# Altium schematic sheet heater_control.SchDoc
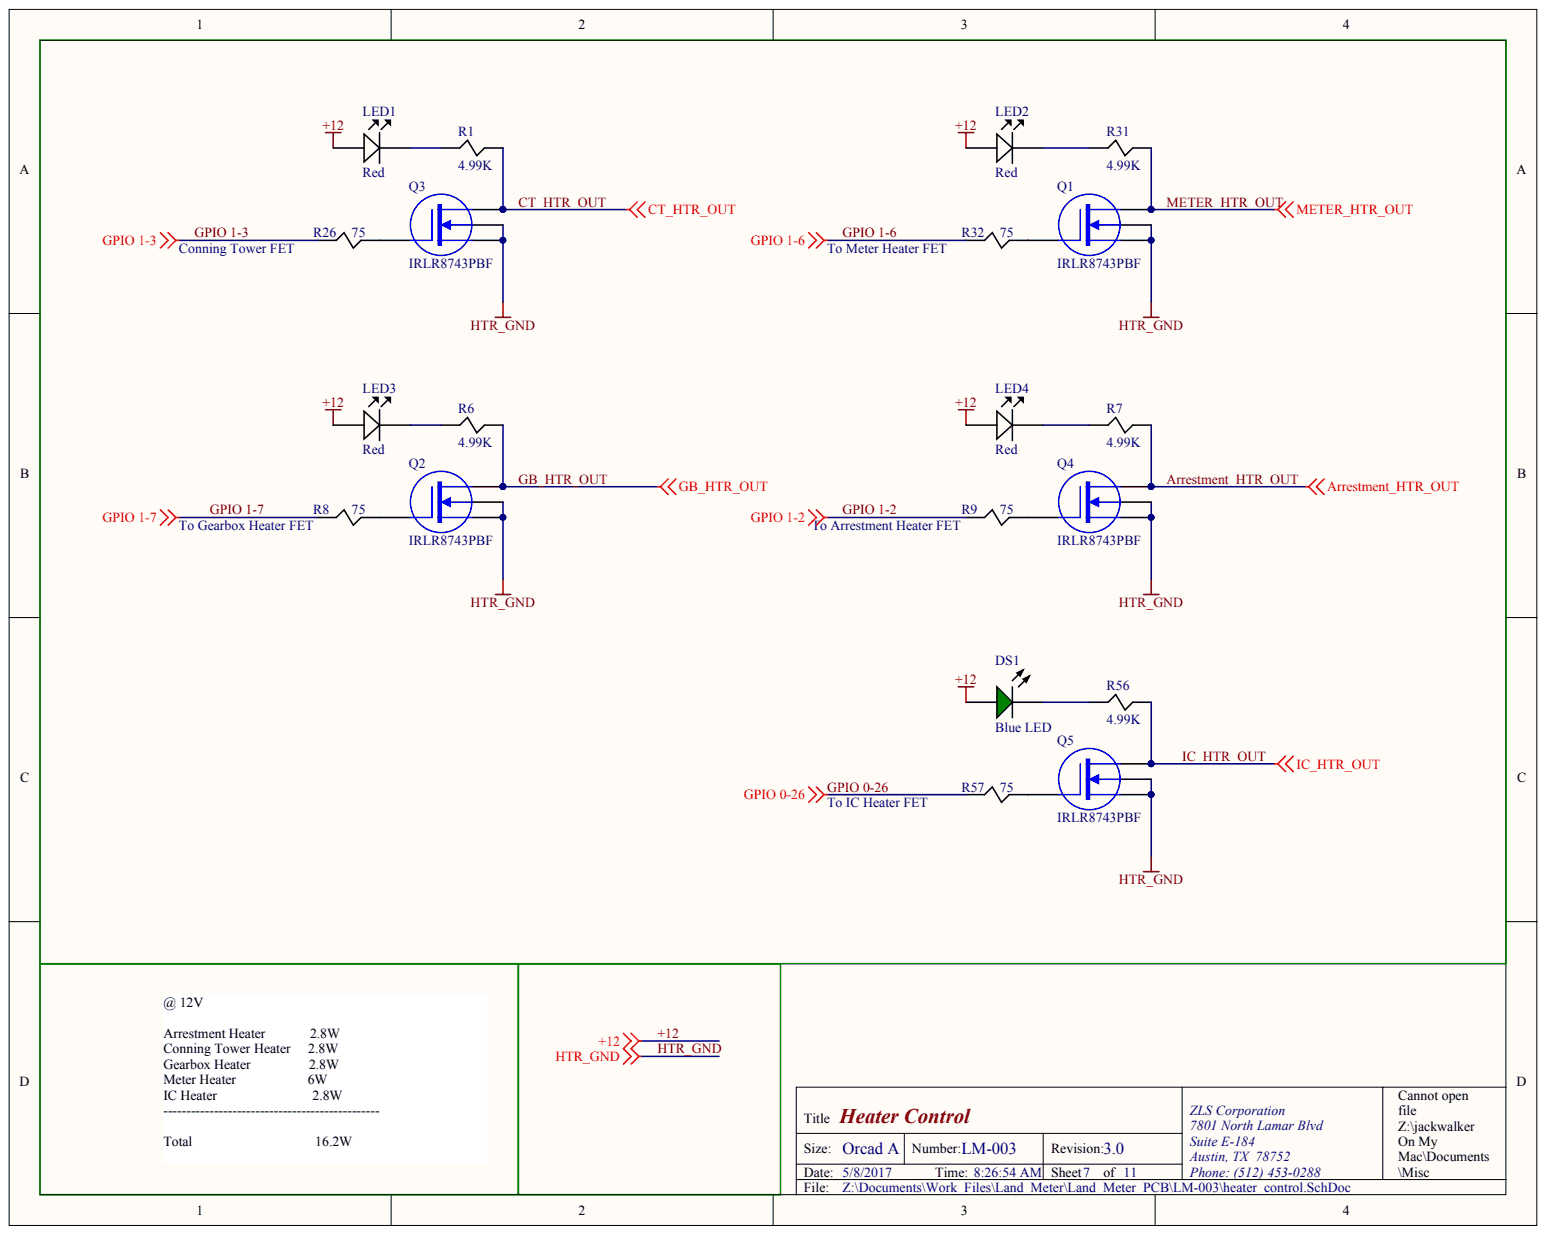
[diagram p0: heater_control.SchDoc]

## Parts
DS1  Blue LED  Color: Blue
LED1  Red
LED2  Red
LED3  Red
LED4  Red
Q1  IRLR8743PBF
Q2  IRLR8743PBF
Q3  IRLR8743PBF
Q4  IRLR8743PBF
Q5  IRLR8743PBF
R1  4.99K
R6  4.99K
R7  4.99K
R8  75
R9  75
R26  75
R31  4.99K
R32  75
R56  4.99K
R57  75

## Nets
+12: DS1.1 LED1.A LED2.A LED3.A LED4.A
ARRESTMENT_HTR_OUT: Q4.2 R7.2
CT_HTR_OUT: Q3.2 R1.2
GB_HTR_OUT: Q2.2 R6.2
GPIO 0-26: R57.1
GPIO 1-2: R9.1
GPIO 1-3: R26.1
GPIO 1-6: R32.1
GPIO 1-7: R8.1
HTR_GND: Q1.3 Q1.4 Q2.3 Q2.4 Q3.3 Q3.4 Q4.3 Q4.4 Q5.3 Q5.4
IC_HTR_OUT: Q5.2 R56.2
METER_HTR_OUT: Q1.2 R31.2
NetDS1_2: DS1.2 R56.1
NetLED1_K: LED1.K R1.1
NetLED2_K: LED2.K R31.1
NetLED3_K: LED3.K R6.1
NetLED4_K: LED4.K R7.1
NetQ1_1: Q1.1 R32.2
NetQ2_1: Q2.1 R8.2
NetQ3_1: Q3.1 R26.2
NetQ4_1: Q4.1 R9.2
NetQ5_1: Q5.1 R57.2

## Library data of the parts
DS1 = (no symbol name): Manufacturer 1: Lite-On Inc; Manufacturer Part Number 1: LTST-C191TBKT; Description 1: LED 468NM BLUE CLEAR 0603 SMD; Category 1: Optoelectronics; Wavelength - Dominant: 467.5nm; Wavelength - Peak: 468nm; Millicandela Rating: 30mcd; Voltage - Forward (Vf) (Typ): 3.4V; Current - Test: 20mA; Viewing Angle: 130°; Lens Type: Clear; Lens Style/Size: Rectangle with Flat Top, 1.1mm x 0.8mm; Supplier 1: Digi-Key; Supplier Part Number 1: 160-1647-1-ND; RoHS 1: RoHS Compliant; Stock 1: 267074; Pricing 1: 1=0.46, 10=0.342, 100=0.1813, 250=0.12824, 500=0.10944, 1000=0.0855, 2500=0.07524 (USD); Packaging: Cut Tape (CT); Package / Case: 0603 (1608 Metric); Size / Dimension: 1.60mm L x 0.80mm W; Height: 0.55mm; Mounting Type: Surface Mount; Luminous Flux @ Current - Test: -; ComponentLink1URL: http://optoelectronics.liteon.com/upload/download/DS22-2000-230/S_110_LTST-C191TBKT.pdf; ComponentLink1Description: http://optoelectronics.liteon.com/upload/download/DS22-2000-230/S_110_LTST-C191TBKT.pdf
LED1-LED4 = (no symbol name): Manufacturer 1: Dialight; Manufacturer Part Number 1: 5660406F; Description 1: LED 2MM X 5MM RT ANGLE RED PCMNT; Category 1: Optoelectronics; Wavelength - Peak: 635nm; Configuration: Single; Current: 20mA; Millicandela Rating: 7.4mcd; Viewing Angle: 140°; Lens Type: Diffused, Tinted; Lens Style/Size: Rectangle with Flat Top, 5.00mm x 2.00mm; Voltage Rating: 2V; Supplier 1: Digi-Key; Supplier Part Number 1: 350-1729-ND; RoHS 1: RoHS Compliant; Stock 1: 530; Pricing 1: 1=1.96, 10=1.595, 25=1.2272, 100=1.1044, 250=0.98172, 500=0.83446, 1000=0.6872, 2500=0.65284, 5000=0.63812 (USD); Packaging: Bulk; Part Status: Active; Color: Red; Mounting Type: Through Hole, Right Angle; ComponentLink1URL: http://www.dialight.com/Assets/Brochures_And_Catalogs/Indication/566-xx06.pdf; ComponentLink1Description: http://www.dialight.com/Assets/Brochures_And_Catalogs/Indication/566-xx06.pdf
Q1-Q5 = (no symbol name): Manufacturer 1: Infineon Technologies; Description 1: MOSFET N-CH 30V 160A DPAK; Category 1: Discrete Semiconductor Products; FET Type: N-Channel; Drain to Source Voltage (Vdss): 30V; Current - Continuous Drain (Id) @ 25°C: 160A (Tc); Drive Voltage (Max Rds On,  Min Rds On): 4.5V, 10V; Vgs(th) (Max) @ Id: 2.35V @ 100µA; Gate Charge (Qg) (Max) @ Vgs: 59nC @ 4.5V; Input Capacitance (Ciss) (Max) @ Vds: 4880pF @ 15V; Vgs (Max): ±20V; Power Dissipation (Max): 135W (Tc); Rds On (Max) @ Id, Vgs: 3.1 mOhm @ 25A, 10V; Supplier 1: Digi-Key; Supplier Part Number 1: IRLR8743PBF-ND; Manufacturer Part Number 1: IRLR8743PBF; RoHS 1: RoHS Compliant; Stock 1: 557; Pricing 1: 1=1.29, 10=1.152, 100=0.8984, 500=0.74214, 1000=0.5859 (USD); Packaging: Tube; Part Status: Not For New Designs; Technology: MOSFET (Metal Oxide); FET Feature: -; Operating Temperature: -55°C ~ 175°C (TJ); Mounting Type: Surface Mount; Supplier Device Package: D-Pak; Package / Case: TO-252-3, DPak (2 Leads + Tab), SC-63; ComponentLink1URL: http://www.irf.com/product-info/datasheets/data/irlr8743pbf.pdf; ComponentLink1Description: http://www.irf.com/product-info/datasheets/data/irlr8743pbf.pdf
R1 R6 R7 R31 R56 = (no symbol name): Manufacturer 1: Vishay Dale; Manufacturer Part Number 1: CRCW08054R99FKEA; Description 1: RES SMD 4.99 OHM 1% 1/8W 0805; Category 1: Resistors; Resistance (Ohms): 4.99; Tolerance: ±1%; Power (Watts): 0.125W, 1/8W; Temperature Coefficient: ±100ppm/°C; Supplier 1: Digi-Key; Supplier Part Number 1: 541-4.99CCCT-ND; RoHS 1: RoHS Compliant; Stock 1: 26999; Pricing 1: 1=0.1, 10=0.042, 25=0.0304, 50=0.0232, 100=0.017, 250=0.013, 500=0.0104, 1000=0.00765 (USD); Packaging: Cut Tape (CT); Part Status: Active; Composition: Thick Film; Features: Automotive AEC-Q200; Operating Temperature: -55°C ~ 155°C; Package / Case: 0805 (2012 Metric); Supplier Device Package: 0805; Size / Dimension: 0.079" L x 0.049" W (2.00mm x 1.25mm); Height - Seated (Max): 0.020" (0.50mm); Failure Rate: -; ComponentLink1URL: http://www.vishay.com/docs/20035/dcrcwe3.pdf; ComponentLink1Description: http://www.vishay.com/docs/20035/dcrcwe3.pdf
R8 R9 R26 R32 R57 = (no symbol name): Manufacturer 1: Vishay Dale; Manufacturer Part Number 1: CRCW080575R0FKEA; Description 1: RES SMD 75 OHM 1% 1/8W 0805; Category 1: Resistors; Tolerance: ±1%; Power (Watts): 0.125W, 1/8W; Temperature Coefficient: ±100ppm/°C; Supplier 1: Digi-Key; Supplier Part Number 1: 541-75.0CCT-ND; RoHS 1: RoHS Compliant; Stock 1: 8423; Pricing 1: 1=0.1, 10=0.042, 25=0.0304, 50=0.0232, 100=0.017, 250=0.013, 500=0.0104, 1000=0.00765 (USD); Packaging: Cut Tape (CT); Part Status: Active; Resistance (Ohms): 75; Composition: Thick Film; Features: Automotive AEC-Q200; Operating Temperature: -55°C ~ 155°C; Package / Case: 0805 (2012 Metric); Supplier Device Package: 0805; Size / Dimension: 0.079" L x 0.049" W (2.00mm x 1.25mm); Height - Seated (Max): 0.020" (0.50mm); Failure Rate: -; ComponentLink1URL: http://www.vishay.com/docs/20035/dcrcwe3.pdf; ComponentLink1Description: http://www.vishay.com/docs/20035/dcrcwe3.pdf
